# Altium schematic sheet PAGE2 - LED, RCV.SchDoc
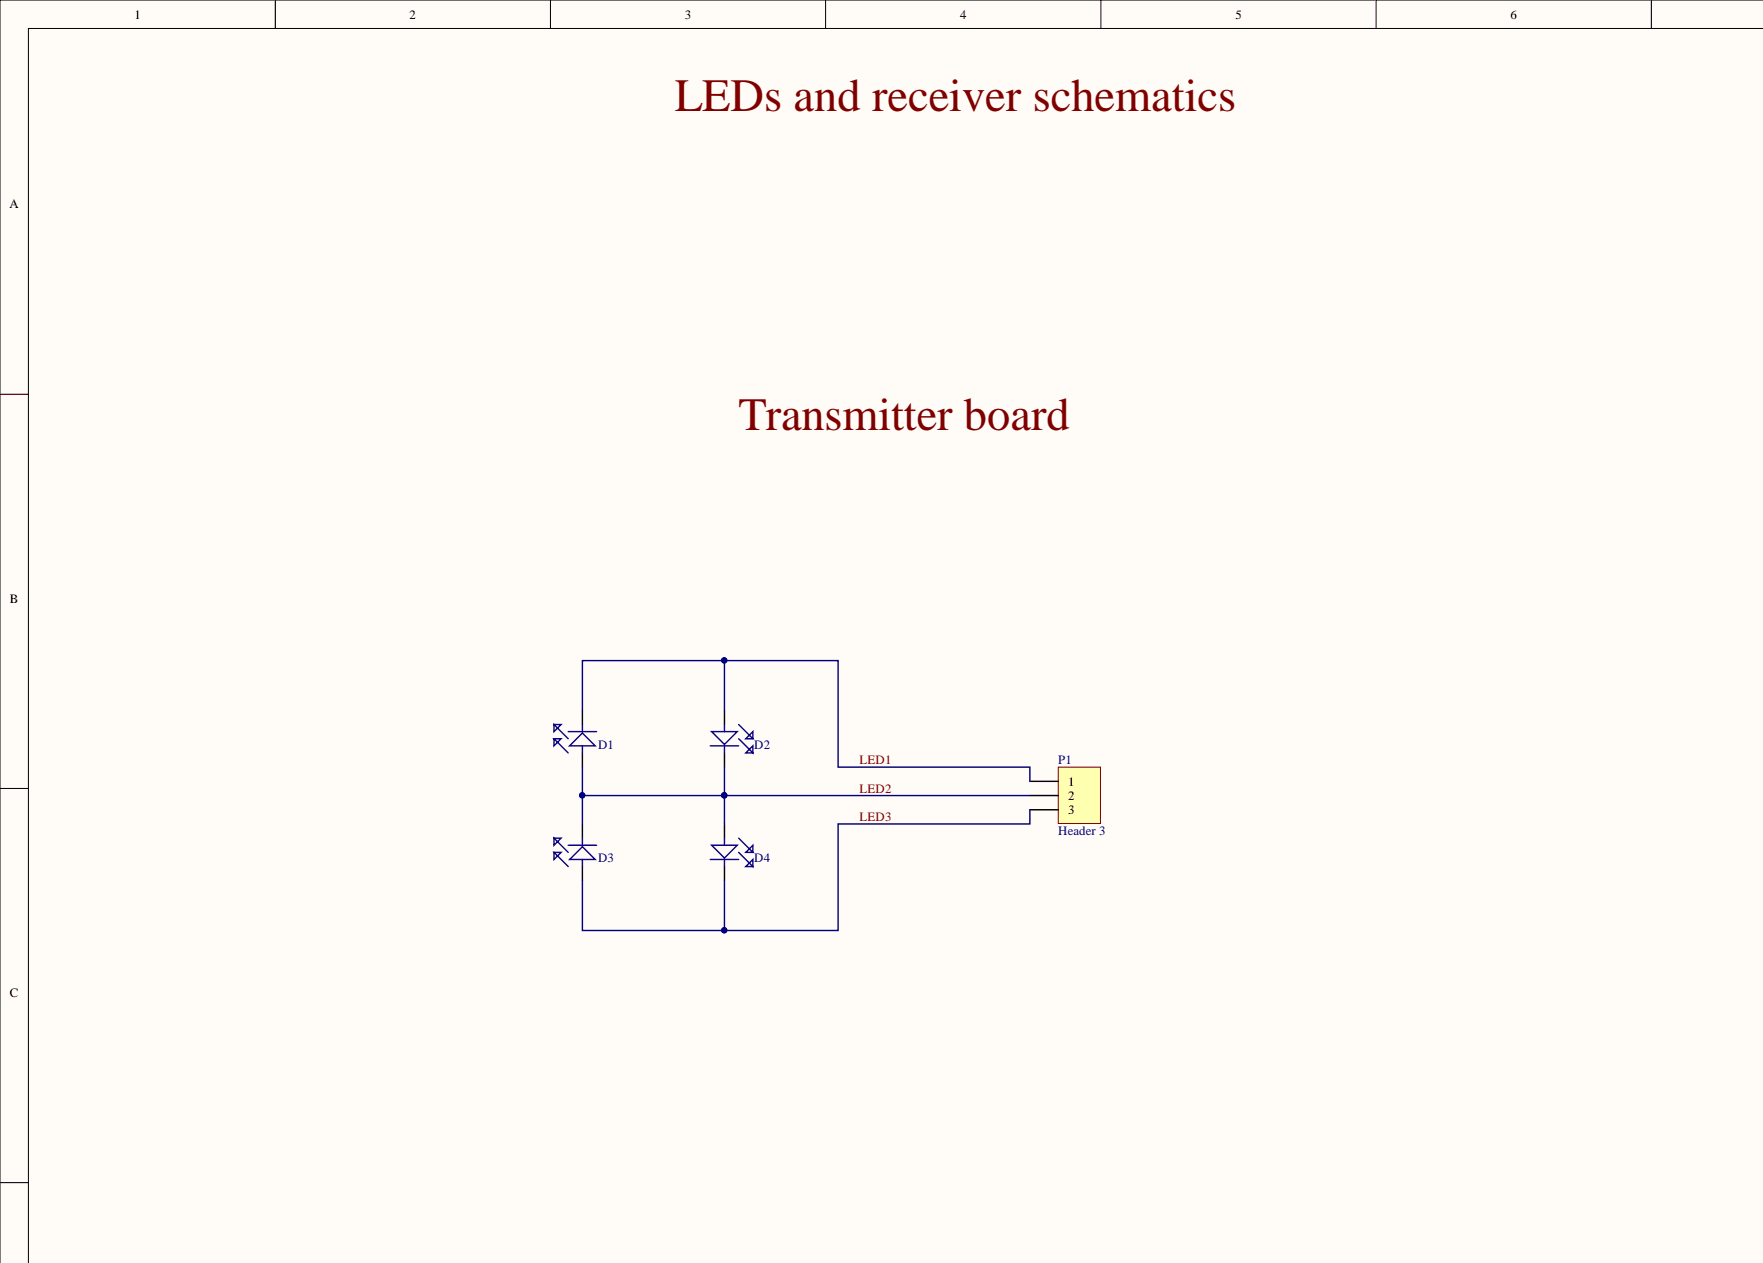
[diagram p0: PAGE2 - LED, RCV.SchDoc]

## Parts
D1  598-8030-107F  [LED0603]  (value not drawn)
D2  SML-LX0603SRW-TR  [LED0603]  (value not drawn)
D3  APT1608F3C  [LED0603]  (value not drawn)
D4  SML-LX0603SYW-TR  [LED0603]  (value not drawn)
P1  Header 3  [HDR1X3]  (value not drawn)

## Nets
LED1: D1.2 D2.1 P1.1
LED2: D1.1 D2.2 D3.2 D4.1 P1.2
LED3: D3.1 D4.2 P1.3

## Library data of the parts
D1 = 598-8030-107F: Standard LEDs - SMD Orange Water Clr 150mcd 610nm; Brand: Dialight; If - Forward Current: 20 mA; Lens Colour/Style: Water Clear; Lens Shape: Rectangular; Luminous Intensity: 70 mcd to 150 mcd; Vf - Forward Voltage: 1.7 V; Viewing Angle: 140 deg; Wavelength: 600 nm to 610 nm; Category: Standard LEDs - SMD; Colour Temperature: -; Component Kind: Standard; ComponentLink1Description: http://www.mouser.com/ds/2/109/SMT_selector_guide-267443.pdf; ComponentLink1URL: http://www.mouser.com/ds/2/109/SMT_selector_guide-267443.pdf; Height: 0.7 mm; Illumination Colour: Orange; Length: 1.6 mm; Lens Dimensions: 1.1 mm x 0.8 mm; Manufacturer: Dialight; Manufacturer Part Number: 598-8030-107F; Maximum Operating Temperature: + 85 C; Minimum Operating Temperature: - 30 C; Minimum Order Quantity: 1; Mounting Style: SMD/SMT; Orientation: Top View; Package/Case: 0603 (1608 metric); Packaging: Reel; Pricing 1: 1=0.357, 10=0.210, 100=0.121, 1000=0.094, 4000=0.081, 8000=0.074, 24000=0.069, 48000=0.063, 100000=0.062 (EUR); Reeling: True; RoHS: RoHS Compliant; RoHS - Mouser: Y; Standard Pack Qty: 4000; Stock 1: 11067; Supplier 1: Mouser; Supplier Part Number 1: 645-598-8030-107F; Wavelength/Colour Temperature: 600 nm to 610 nm; Width: 0.8 mm; Library Name: pulseox-clamp-PCB-SCHLib.SchLib
D2 = SML-LX0603SRW-TR: Standard LEDs - SMD Super Red, 660nm 1.7V, 45mcd; Brand: Lumex; If - Forward Current: 20 mA; Lens Colour/Style: Milky White Diffused; Lens Shape: Rectangular; Viewing Angle: 140 deg; Category: Standard LEDs - SMD; Colour Temperature: -; Component Kind: Standard; ComponentLink1Description: http://www.mouser.com/ds/2/244/SML-LX0603SRW-TR-1135914.pdf; ComponentLink1URL: http://www.mouser.com/ds/2/244/SML-LX0603SRW-TR-1135914.pdf; Illumination Colour: Red; Length: 1.6 mm; Luminous Intensity: 45 mcd; Manufacturer: Lumex; Manufacturer Part Number: SML-LX0603SRW-TR; Maximum Operating Temperature: + 85 C; Minimum Operating Temperature: - 25 C; Minimum Order Quantity: 1; Mounting Style: SMD/SMT; Package/Case: 0603 (1608 metric); Packaging: Reel; Pricing 1: 1=0.281, 10=0.171, 100=0.107, 500=0.078, 1000=0.061, 2000=0.060, 4000=0.054, 8000=0.048, 24000=0.043 (EUR); Reeling: True; RoHS: RoHS Compliant; RoHS - Mouser: Y; Standard Pack Qty: 4000; Stock 1: 29046; Supplier 1: Mouser; Supplier Part Number 1: 696-SML-LX0603SRW; Vf - Forward Voltage: 1.7 V; Wavelength: 660 nm; Wavelength/Colour Temperature: 660 nm; Width: 0.8 mm; Library Name: pulseox-clamp-PCB-SCHLib.SchLib
D3 = APT1608F3C: Infrared Emitters IR 940nm 120 deg Water Clr 1.2 mW/sr; Beam Angle: 120 deg; Brand: Kingbright; If - Forward Current: 20 mA; Lens Shape: Rectangular; Power Rating: 80 mW; Vf - Forward Voltage: 1.2 V; Vr - Reverse Voltage: 5 V; Category: Infrared Emitters; Component Kind: Standard; ComponentLink1Description: http://www.mouser.com/ds/2/216/APT1608F3C-80735.pdf; ComponentLink1URL: http://www.mouser.com/ds/2/216/APT1608F3C-80735.pdf; Height: 0.75 mm; Illumination Colour: Infrared; Length: 1.6 mm; Lens Dimensions: 1.2 mm x 0.8 mm; Manufacturer: Kingbright; Manufacturer Part Number: APT1608F3C; Maximum Operating Temperature: + 85 C; Minimum Operating Temperature: - 40 C; Minimum Order Quantity: 1; Mounting Style: SMD/SMT; Package/Case: 0603; Packaging: Reel; Pricing 1: 1=0.221, 10=0.137, 100=0.102, 1000=0.083, 2000=0.075, 10000=0.068, 24000=0.061, 50000=0.058, 100000=0.055 (EUR); Radiant Intensity: 1.2 mW/sr; Reeling: True; RoHS: RoHS Compliant; RoHS - Mouser: Y; Standard Pack Qty: 2000; Stock 1: 9952; Supplier 1: Mouser; Supplier Part Number 1: 604-APT1608F3C; Viewing Angle: 120 deg; Wavelength: 940 nm; Width: 0.8 mm; Library Name: pulseox-clamp-PCB-SCHLib.SchLib
D4 = SML-LX0603SYW-TR: Standard LEDs - SMD Super Yellow, 590nm 2V, 60mcd; Brand: Lumex; If - Forward Current: 20 mA; Lens Colour/Style: White Diffused; Lens Shape: Rectangular; Power Rating: 50 mW; Viewing Angle: 140 deg; Vr - Reverse Voltage: 5 V; Category: Standard LEDs - SMD; Colour Temperature: -; Component Kind: Standard; ComponentLink1Description: http://www.mouser.com/ds/2/244/SML-LX0603SYW-TR-1136026.pdf; ComponentLink1URL: http://www.mouser.com/ds/2/244/SML-LX0603SYW-TR-1136026.pdf; Height: 0.6 mm; Illumination Colour: Yellow; Length: 1.6 mm; Lens Dimensions: 1 mm x 0.8 mm; Luminous Intensity: 60 mcd; Manufacturer: Lumex; Manufacturer Part Number: SML-LX0603SYW-TR; Maximum Operating Temperature: + 85 C; Minimum Operating Temperature: - 25 C; Minimum Order Quantity: 1; Mounting Style: SMD/SMT; Package/Case: 0603 (1608 metric); Packaging: Reel; Peak Wavelength: 590 nm; Pricing 1: 1=0.434, 10=0.283, 100=0.218, 500=0.187, 1000=0.145, 2000=0.119, 4000=0.111, 8000=0.107, 24000=0.105 (EUR); Reeling: True; RoHS: RoHS Compliant; RoHS - Mouser: Y; Standard Pack Qty: 4000; Stock 1: 11431; Supplier 1: Mouser; Supplier Part Number 1: 696-SML-LX0603SYW; Vf - Forward Voltage: 2 V; Wavelength: 590 nm; Wavelength/Colour Temperature: 590 nm; Width: 0.8 mm; Library Name: pulseox-clamp-PCB-SCHLib.SchLib
P1 = Header 3: Header, 3-Pin; Component Kind: Standard; LatestRevisionDate: 17-Jul-2002; LatestRevisionNote: Re-released for DXP Platform.; Library Name: Miscellaneous Connectors.IntLib; Signal Integrity: Connector; Publisher: Altium Limited
